# Altium schematic sheet GSM.SchDoc
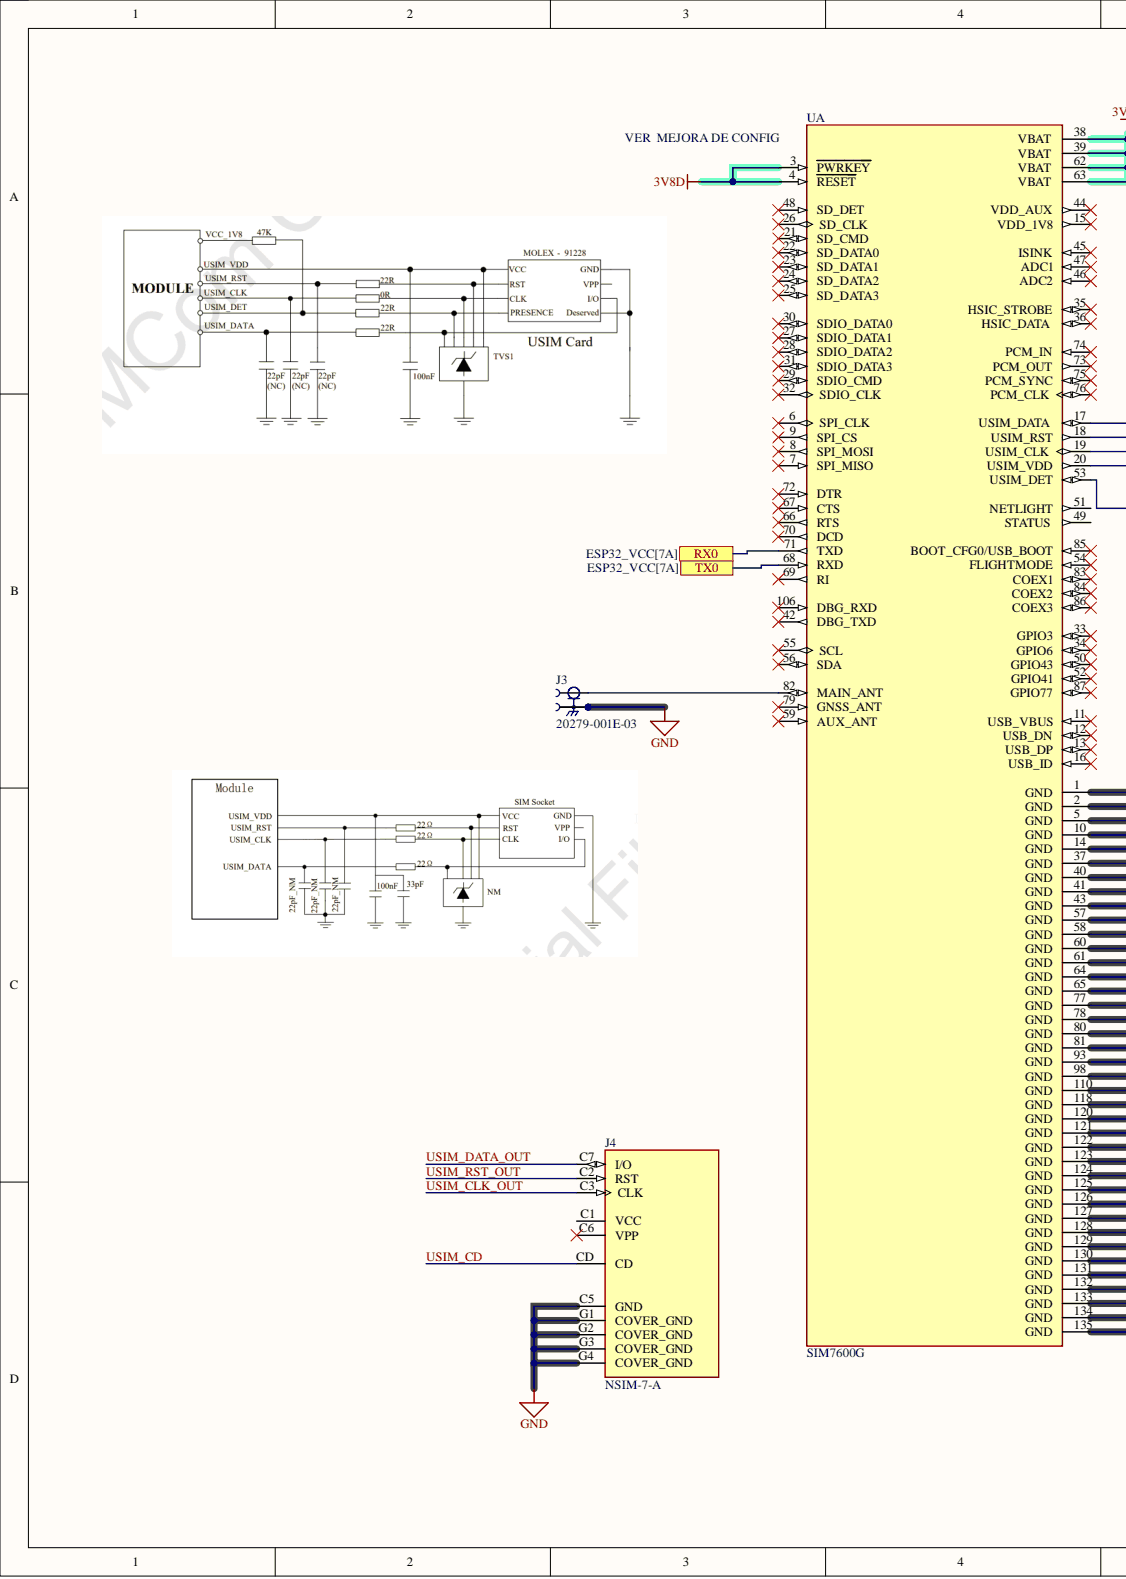
[diagram p0: GSM.SchDoc, left]
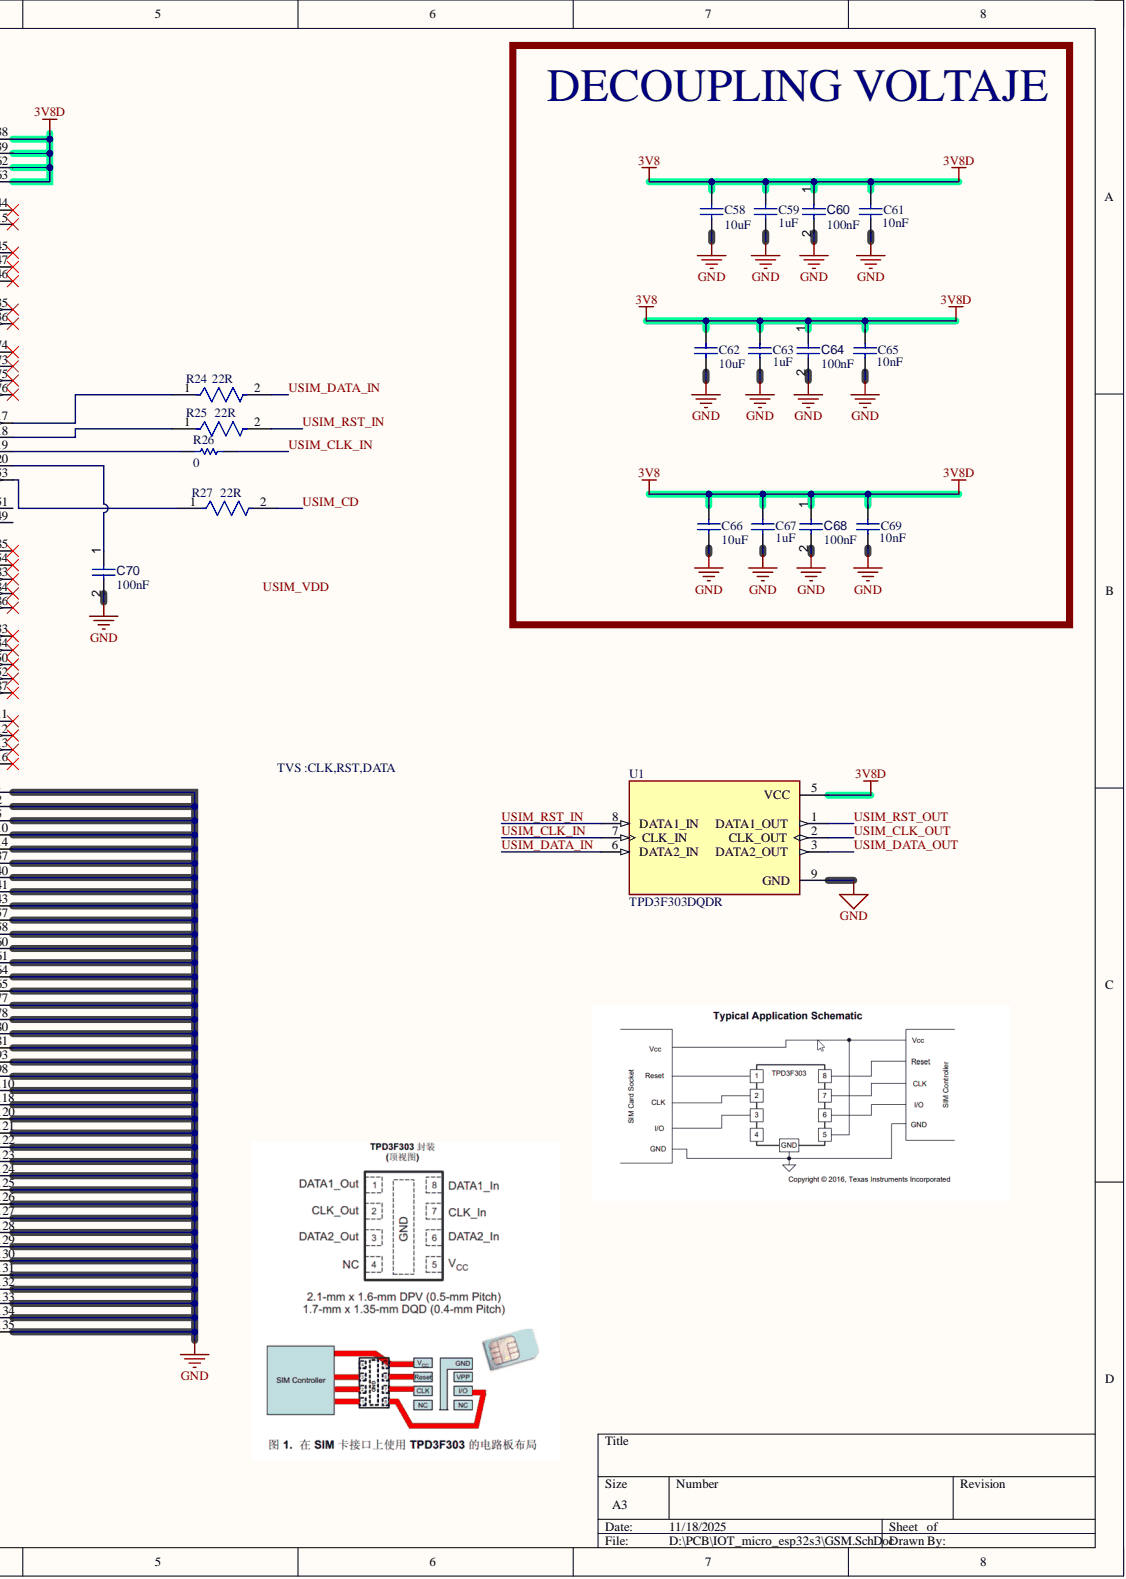
[diagram p1: GSM.SchDoc, right]

## Parts
C58  value 10uF  [CAPC0603(1608)100_M]  (hidden comment: CAP 10uF 6.3V 0603(1608))
C59  value 1uF  [CAPC1608X90X35ML15T15]  (hidden comment: 0603YD105KAT4A)
C60  value 100nF  [CAPC1005X56X25NL10T15]  (hidden comment: Capacitor 0.1 uF +/- 5% 16 V 0402)
C61  value 10nF  [CAPC0603X33X15NL03T05]  (hidden comment: 0201ZC103JAT2A)
C62  value 10uF  [CAPC0603(1608)100_M]  (hidden comment: CAP 10uF 6.3V 0603(1608))
C63  value 1uF  [CAPC1608X90X35ML15T15]  (hidden comment: 0603YD105KAT4A)
C64  value 100nF  [CAPC1005X56X25NL10T15]  (hidden comment: Capacitor 0.1 uF +/- 5% 16 V 0402)
C65  value 10nF  [CAPC0603X33X15NL03T05]  (hidden comment: 0201ZC103JAT2A)
C66  value 10uF  [CAPC0603(1608)100_M]  (hidden comment: CAP 10uF 6.3V 0603(1608))
C67  value 1uF  [CAPC1608X90X35ML15T15]  (hidden comment: 0603YD105KAT4A)
C68  value 100nF  [CAPC1005X56X25NL10T15]  (hidden comment: Capacitor 0.1 uF +/- 5% 16 V 0402)
C69  value 10nF  [CAPC0603X33X15NL03T05]  (hidden comment: 0201ZC103JAT2A)
C70  value 100nF  [CAPC1005X56X25NL10T15]  (hidden comment: Capacitor 0.1 uF +/- 5% 16 V 0402)
J3  20279-001E-03  [IPEX_20279-001E-03]
J4  NSIM-7-A  [CUI_NSIM-7-A]
R24  Resistance: 22R  [FP-CR0603-IPC_A]  (hidden comment: CR0603-JW-220ELF)
R25  Resistance: 22R  [FP-CR0603-IPC_A]  (hidden comment: CR0603-JW-220ELF)
R26  value 0  [RESC1608X55X25ML10T15]  (hidden comment: Resistor 0R +/- 5% 0603 100 mW)
R27  Resistance: 22R  [FP-CR0603-IPC_A]  (hidden comment: CR0603-JW-220ELF)
U  SIM7600G  [IC_SIM7600G]  (part A)
U1  TPD3F303DQDR  [SON40P170X135X80-9N]

## Ports
RX0, TX0

## Nets
3V8: C58.2 C59.1 C60.1 C61.2 C62.2 C63.1 C64.1 C65.2 C66.2 C67.1 C68.1 C69.2 U.3(PWRKEY) U.4(RESET) U.38(VBAT) U.39(VBAT) U.62(VBAT) U.63(VBAT) U1.5(VCC)
GND: C58.1 C59.2 C60.2 C61.1 C62.1 C63.2 C64.2 C65.1 C66.1 C67.2 C68.2 C69.1 C70.2 J3.2 J3.3 J4.C5(GND) J4.G1(COVER_GND) J4.G2(COVER_GND) J4.G3(COVER_GND) J4.G4(COVER_GND) U.1(GND) U.2(GND) U.5(GND) U.10(GND) U.14(GND) U.37(GND) U.40(GND) U.41(GND) U.43(GND) U.57(GND) U.58(GND) U.60(GND) U.61(GND) U.64(GND) U.65(GND) U.77(GND) U.78(GND) U.80(GND) U.81(GND) U.93(GND) U.98(GND) U.110(GND) U.118(GND) U.120(GND) U.121(GND) U.122(GND) U.123(GND) U.124(GND) U.125(GND) U.126(GND) U.127(GND) U.128(GND) U.129(GND) U.130(GND) U.131(GND) U.132(GND) U.133(GND) U.134(GND) U.135(GND) U1.9(GND)
RX0: U.71(TXD) ; port RX0
TX0: U.68(RXD) ; port TX0
USIM_CD: J4.CD R27.2
USIM_CLK_IN: R26.2 U1.7(CLK_IN)
USIM_CLK_OUT: J4.C3(CLK) U1.2(CLK_OUT)
USIM_DATA_IN: R24.2 U1.6(DATA2_IN)
USIM_DATA_OUT: J4.C7(I/O) U1.3(DATA2_OUT)
USIM_RST_IN: R25.2 U1.8(DATA1_IN)
USIM_RST_OUT: J4.C2(RST) U1.1(DATA1_OUT)
NetC70_1: C70.1 U.20(USIM_VDD)
NetJ3_1: J3.1 U.82(MAIN_ANT)
NetR24_1: R24.1 U.17(USIM_DATA)
NetR25_1: R25.1 U.18(USIM_RST)
NetR26_1: R26.1 U.19(USIM_CLK)
NetR27_1: R27.1 U.53(USIM_DET)
USIM_VDD:

Not connected: J4 C1(VCC) C6(VPP); U 6(SPI_CLK) 7(SPI_MISO) 8(SPI_MOSI) 9(SPI_CS) 11(USB_VBUS) 12(USB_DN) 13(USB_DP) 15(VDD_1V8) 16(USB_ID) 21(SD_CMD) 22(SD_DATA0) 23(SD_DATA1) 24(SD_DATA2) 25(SD_DATA3) 26(SD_CLK) 27(SDIO_DATA1) 28(SDIO_DATA2) 29(SDIO_CMD) 30(SDIO_DATA0) 31(SDIO_DATA3) 32(SDIO_CLK) 33(GPIO3) 34(GPIO6) 35(HSIC_STROBE) 36(HSIC_DATA) 42(DBG_TXD) 44(VDD_AUX) 45(ISINK) 46(ADC2) 47(ADC1) 48(SD_DET) 49(STATUS) 50(GPIO43) 51(NETLIGHT) 52(GPIO41) 54(FLIGHTMODE) 55(SCL) 56(SDA) 59(AUX_ANT) 66(RTS) 67(CTS) 69(RI) 70(DCD) 72(DTR) 73(PCM_OUT) 74(PCM_IN) 75(PCM_SYNC) 76(PCM_CLK) 79(GNSS_ANT) 83(COEX1) 84(COEX2) 85(BOOT_CFG0/USB_BOOT) 86(COEX3) 87(GPIO77) 106(DBG_RXD)

## Library data of the parts
C58 C62 C66 = CMP-1035-02796-1: CAP 10uF 6.3V ±10% 0603 (1608 Metric) Thickness 1mm SMD; Case-EIA: 0603; Case-Metric: 1608; Tolerance: Â±10%; Component Kind: Standard; Library Name: Altium Content Vault; Max Thickness: 1 mm; Rated Voltage: 6.3 V; Technology: SMT
C59 C63 C67 = CMP-2006-04533-1: Manufacturer: AVX Interconnect / Elco; Component Kind: Standard; Library Name: Altium Content Vault; Part Number: 0603YD105KAT4A
C60 C64 C68 C70 = CMP-001-00001-6: Chip Capacitor, 0.1 uF, +/- 5%, 16 V, 0402 (1005 Metric); Capacitor Type: Ceramic; Voltage Rating: 16V; Case/Package: 0402; Component Kind: Standard; Max Operating Temperature: 125°C; Min Operating Temperature: -55°C; Mounting Technology: SM; Pins: 2; RoHS Compliant: True; Tolerance: 5%; Library Name: Carlos Christopher Carbajal Jordán
C61 C65 C69 = CMP-2010-00529-1: Manufacturer: AVX Interconnect / Elco; Component Kind: Standard; Library Name: Altium Content Vault; Part Number: 0201ZC103JAT2A
J3 = CMP-002-00077-1: 1 POS Vertical SMD Small form factor with big performance through 6 GHz connector,MHF, RF, micro-coaxial MHF I Receptacle; MF: I-PEX; Case/Package: None; Check_prices: https://www.snapeda.com/parts/20279-001E-03/I-PEX/view-part/?ref=eda; Component Kind: Standard; Component Type: Standard; Design Item ID: 20279-001E-03; MP: 20279-001E-03; Pins: 3; SnapEDA_Link: https://www.snapeda.com/parts/20279-001E-03/I-PEX/view-part/?ref=snap; Source: 20279-001E-03.SchLib; Library Name: Carlos Christopher Carbajal Jordán
J4 = CMP-002-00079-3: Nano SIM Card Connectors, 7 Positions, Connector and Ejector, Push In, Auto Eject Out, Surface Mount, 1.37 mm Height Above Board, Gold Flash; MF: Same Sky; Case/Package: None; Check_prices: https://www.snapeda.com/parts/NSIM-7-A/Same+Sky/view-part/?ref=eda; Component Kind: Standard; Component Type: Standard; Design Item ID: NSIM-7-A; MP: NSIM-7-A; Pins: 11; SnapEDA_Link: https://www.snapeda.com/parts/NSIM-7-A/Same+Sky/view-part/?ref=snap; Source: NSIM-7-A.SchLib; Library Name: Carlos Christopher Carbajal Jordán
R24 R25 R27 = CMP-07231-005384-1: Thick Film Chip Resistors 0603 22Ω 0.1W 5% 200ppm/°C; Max Power Dissipation: 100mW; Voltage Rating: 50V; Case Code (Imperial): 0603; Case Code (Metric): 1608; Case/Package: 0603; Component Kind: Standard; Composition: Thick Film; Contact Plating: Tin; Depth: 0.8mm; Features: Moisture Resistant; Height: 0.022inch; Length: 1.6mm; Library Name: Altium Content Vault; Max Operating Temperature: 155°C; Military Standard: Not; Min Operating Temperature: -55°C; Mount: Surface Mount; Number of Pins: 2; Number of Terminations: 2; Package Quantity: 1; Packaging: Tape and Reel; Power Rating: 100mW; Radiation Hardening: No; REACH SVHC: No SVHC; Temperature Coefficient: 200ppm/°C; Termination: SMD/SMT; Thickness: 457um; Tolerance: 5%; Voltage Rating (DC): 50V; Width: 0.8mm
R26 = CMP-009-00006-5: Chip Resistor, 0 Ohm, 0.1 W, -55 to 155 degC, 0603 (1608 Metric); Power: 100mW; Voltage Rating: 50V; Case/Package: 0603; Component Kind: Standard; Max Operating Temperature: 155°C; Min Operating Temperature: -55°C; Mounting Technology: SM; Pins: 2; RoHS Compliant: True; Tolerance: 5%; Library Name: Carlos Christopher Carbajal Jordán
U = CMP-014-000000-1: LTE Cat-4 Module; MF: Simcom; Availability: Unavailable; Component Kind: Standard; Component Type: Standard; Design Item ID: SIM7600G; MP: SIM7600G; Package: Package Analog Devices; Pin Count: 135; Price: None; SnapEDA_Link: https://www.snapeda.com/parts/SIM7600G/SIMcom%20Wireless%20solutions%20Co.%2CLtd/view-part/4001333/?ref=snap; Source: SnapEDAsch.SchLib; Library Name: Carlos Christopher Carbajal Jordán
U1 = CMP-022-000000-1: RC _Pi_ EMI Filter 2nd Order Low Pass 3 Channel R = 47Ohms, 100Ohms, C = 20pF 8-WFDFN Exposed Pad; MF: Texas Instruments; Availability: Unavailable; Component Kind: Standard; Component Type: Standard; Design Item ID: TPD3F303DQDR; MP: TPD3F303DQDR; Package: WFDFN-8 Texas Instruments; Pin Count: 8; Price: None; SnapEDA_Link: https://www.snapeda.com/parts/TPD3F303DQDR/Texas%20Instruments/view-part/499614/?ref=snap; Source: SnapEDAsch.SchLib; Library Name: Carlos Christopher Carbajal Jordán
